# Altium schematic sheet power.SchDoc
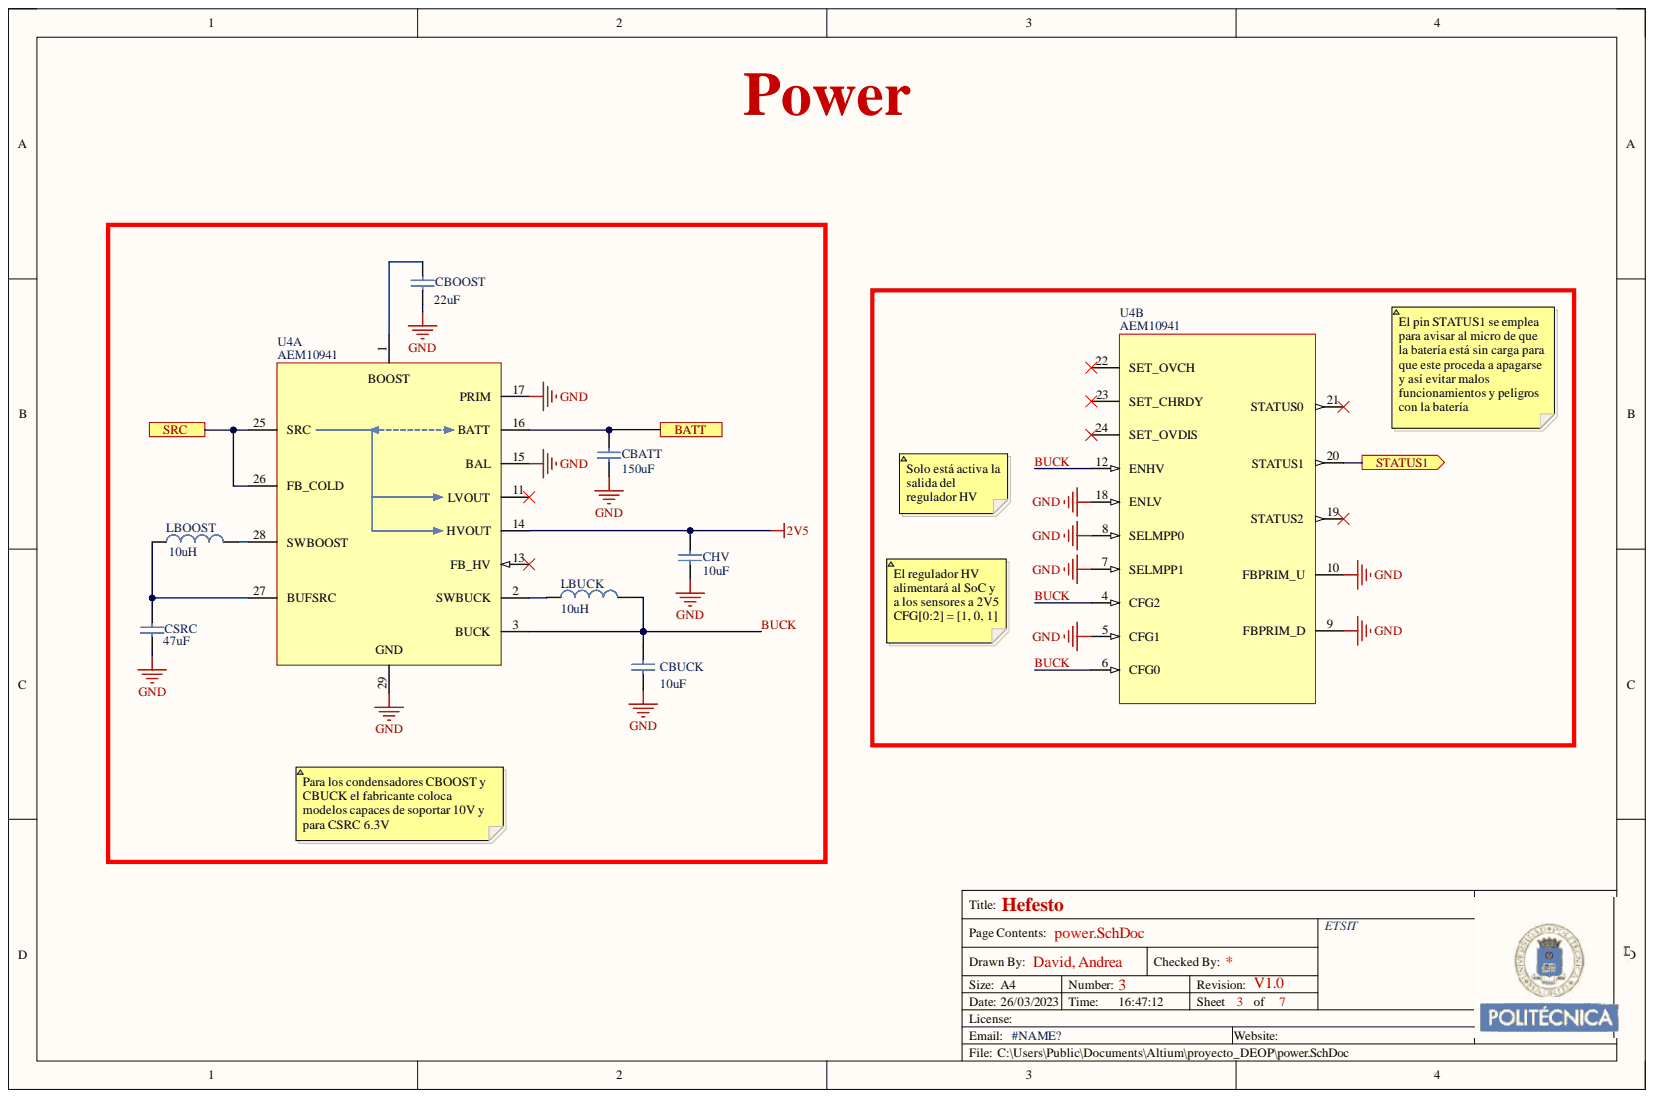
[diagram p0: power.SchDoc]

## Parts
CBATT  value 150uF  [1608[0603]]
CBOOST  value 22uF  [1608[0603]]  (hidden comment: Cap Semi)
CBUCK  value 10uF  [1608[0603]]
CHV  value 10uF  [1608[0603]]
CSRC  value 47uF  [1608[0603]]  (hidden comment: Cap Semi)
LBOOST  LBOOST: 10uH  [0402-A]
LBUCK  LBOOST: 10uH  [0402-A]
U4  AEM10941  [AEM10941]  (parts A,B)

## Ports
BATT, SRC, STATUS1

## Nets
2V5: CHV.2
GND: CBATT.1 CBOOST.1 CBUCK.1 CHV.1 CSRC.1 U4.5(CFG1) U4.7(SELMPP1) U4.8(SELMPP0) U4.9(FBPRIM_D) U4.10(FBPRIM_U) U4.15(BAL) U4.17(PRIM) U4.18(ENLV) U4.29
BATT: CBATT.2 U4.16(BATT) ; port BATT
BUCK: CBUCK.2 LBUCK.1 U4.3(BUCK) U4.4(CFG2) U4.6(CFG0) U4.12(ENHV)
SRC: U4.25(SRC) U4.26(FB_COLD) ; port SRC
STATUS1: U4.20(STATUS1) ; port STATUS1
NetCBOOST_2: CBOOST.2 U4.1
NetCSRC_2: CSRC.2 LBOOST.1 U4.27(BUFSRC)
NetLBOOST_2: LBOOST.2 U4.28(SWBOOST)
NetLBUCK_2: LBUCK.2 U4.2(SWBUCK)
NetU4_11: U4.11(LVOUT)
NetU4_13: U4.13(FB_HV)
NetU4_14: U4.14(HVOUT)
NetU4_19: U4.19(STATUS2)
NetU4_21: U4.21(STATUS0)
NetU4_22: U4.22(SET_OVCH)
NetU4_23: U4.23(SET_CHRDY)
NetU4_24: U4.24(SET_OVDIS)

## Library data of the parts
CBATT CBOOST CBUCK CHV CSRC = Cap Semi: Capacitor (Semiconductor SIM Model); Component Kind: Standard; LatestRevisionDate: 29-May-2009; LatestRevisionNote: IPC-7351 Footprint Added.; Library Name: Miscellaneous Devices.IntLib; PackageDescription: Chip Capacitor; PackageReference: C1206; Published: 8-Jun-2000; Signal Integrity: Cap Semi; Simulation: CAP; Publisher: Altium Limited
LBOOST LBUCK = Inductor: Code_IEC: 1005; Code_JEITA: 0402; Component Kind: Standard; Description: Inductor; LatestRevisionDate: 29-May-2009; LatestRevisionNote: IPC-7351 Footprint Added.; Library Name: Miscellaneous Devices.IntLib; PackageDescription: Chip Inductor; PackageReference: 0402-A; Published: 8-Jun-2000; Signal Integrity: Inductor; Simulation: INDUCTOR; Publisher: Altium Limited
U4 = AEM10941: AEM10941 - Symbol QFN28; Component Kind: Standard; Library Name: DEOP2023.IntLib
